# Altium schematic sheet PAGE3-POWER SUPPLY.SchDoc
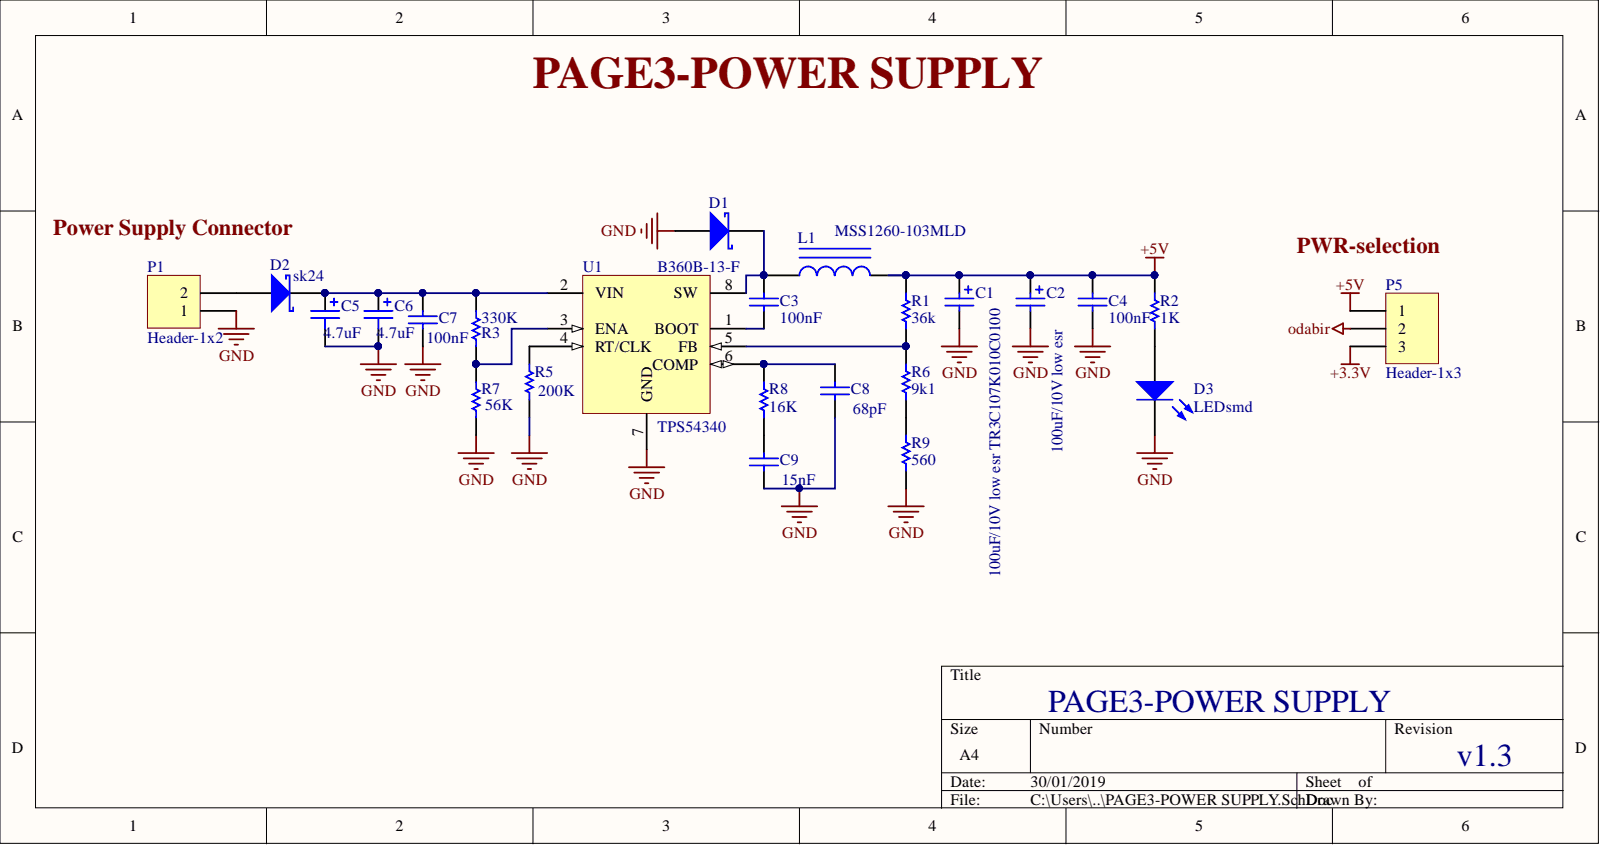
[diagram p0: PAGE3-POWER SUPPLY.SchDoc]

## Parts
C1  value 100uF/10V low esr TR3C107K010C0100  [TC7343-2917]  (hidden comment: CapTantalum)
C2  value 100uF/10V low esr  [TC7343-2917]  (hidden comment: Cap Pol2)
C3  value 100nF  [1206_cap]  (hidden comment: cap_smd1206)
C4  value 100nF  [1206_cap]  (hidden comment: cap_smd1206)
C5  4.7uF  [cap_smd1812]
C6  4.7uF  [cap_smd1812]
C7  100nF  [1206_cap]
C8  value 68pF  [1206_cap]  (hidden comment: cap_smd1206)
C9  value 15nF  [1206_cap]  (hidden comment: cap_smd1206)
D1  B360B-13-F  [DSO-C2/X3.3]
D2  sk24  [DSO-C2/X3.3]
D3  LEDsmd  [led1206]
L1  value MSS1260-103MLD  [INDUCTOR_SS1260-103MLD]  (hidden comment: Inductor_MSS1260)
P1  Header-1x2  [Header2Combination]
P5  Header-1x3  [HDR_1X3]
R1  value 36k  [1206_res]  (hidden comment: res)
R2  value 1K  [1206_res]  (hidden comment: res)
R3  value 330K  [1206_res]  (hidden comment: res)
R5  value 200K  [1206_res]  (hidden comment: res)
R6  value 9k1  [1206_res]  (hidden comment: res)
R7  value 56K  [1206_res]  (hidden comment: res)
R8  value 16K  [1206_res]  (hidden comment: res)
R9  value 560  [1206_res]  (hidden comment: res)
U1  TPS54340  [SOIC_8PIN]

## Nets
+3.3V: P5.3
+5V: C1.1 C2.1 C4.2 L1.2 P5.1 R1.2 R2.2
GND: C1.2 C2.2 C4.1 C5.2 C6.2 C7.1 C8.1 C9.1 D1.1 D3.2 P1.1 R5.1 R7.1 R9.1 U1.7(GND)
ODABIR: P5.2
NetC3_1: C3.1 U1.1(BOOT)
NetC3_2: C3.2 D1.2 L1.1 U1.8(SW)
NetC5_1: C5.1 C6.1 C7.2 D2.2 R3.2 U1.2(VIN)
NetC8_2: C8.2 R8.2 U1.6(COMP)
NetC9_2: C9.2 R8.1
NetD2_1: D2.1 P1.2
NetD3_1: D3.1 R2.1
NetR1_1: R1.1 R6.2 U1.5(FB)
NetR3_1: R3.1 R7.2 U1.3(ENA)
NetR5_2: R5.2 U1.4(RT/CLK)
NetR6_1: R6.1 R9.2

## Library data of the parts
C1 = CapTantalum: Polarized Capacitor (Radial); Value: 100uF/10V low esr TR3C107K010C0100; Component Kind: Standard; LatestRevisionDate: 17-Jul-2002; LatestRevisionNote: Re-released for DXP Platform.; PackageReference: RB7.6-15; Published: 8-Jun-2000; Signal Integrity: Cap Pol; Simulation: CAP; Library Name: hepek_lib.SchLib; Publisher: Altium Limited
C2 = Cap Pol2: Polarized Capacitor (Axial); Component Kind: Standard; LatestRevisionDate: 17-Jul-2002; LatestRevisionNote: Re-released for DXP Platform.; Library Name: Miscellaneous Devices.IntLib; PackageDescription: Polarized Capacitor; 2 Leads; PackageReference: POLAR0.8; Published: 8-Jun-2000; Signal Integrity: Cap Pol; Simulation: CAP; Publisher: Altium Limited
C3 C4 C8 C9 = cap_smd1206: Capacitor; Component Kind: Standard; LatestRevisionDate: 17-Jul-2002; LatestRevisionNote: Re-released for DXP Platform.; PackageReference: RAD-0.3; Published: 8-Jun-2000; Signal Integrity: Cap; Simulation: CAP; Library Name: hepek_lib.SchLib; Publisher: Altium Limited
C5 C6 = cap-smd1812: Polarized Capacitor (Axial); Value: X7R; Component Kind: Standard; LatestRevisionDate: 17-Jul-2002; LatestRevisionNote: Re-released for DXP Platform.; PackageDescription: Polarized Capacitor; 2 Leads; PackageReference: POLAR0.8; Published: 8-Jun-2000; Signal Integrity: Cap Pol; Simulation: CAP; Library Name: hepek_lib.SchLib; Publisher: Altium Limited
C7 = cap_smd: Capacitor; Component Kind: Standard; LatestRevisionDate: 17-Jul-2002; LatestRevisionNote: Re-released for DXP Platform.; PackageReference: RAD-0.3; Published: 8-Jun-2000; Signal Integrity: Cap; Simulation: CAP; Library Name: hepek_lib.SchLib; Publisher: Altium Limited
D1 D2 = SCHOTTKY_RECTIFIER_diode_SMB: Schottky Rectifier; Code_JEDEC: DO-204-AL; Component Kind: Standard; ComponentLink1Description: Manufacturer Link; ComponentLink1URL: http://www.irf.com/; ComponentLink2Description: Datasheet; ComponentLink2URL: http://www.irf.com/product-info/datasheets/data/11dq03.pdf; DatasheetDocument: Dec-1997; LatestRevisionDate: 17-Jul-2002; LatestRevisionNote: Re-released for DXP Platform.; PackageReference: DO-204AL; Published: 8-Jun-2000; Library Name: hepek_lib.SchLib; Publisher: Altium Limited
D3 = LEDsmd: Typical INFRARED GaAs LED; Component Kind: Standard; LatestRevisionDate: 08-Jul-2005; LatestRevisionNote: Mech Layer 1 removed.; PackageReference: LED-0; Published: 8-Jun-2000; Simulation: LED0; Library Name: hepek_lib.SchLib; Publisher: Altium Limited
L1 = Inductor_MSS1260: Magnetic-Core Inductor; Component Kind: Standard; LatestRevisionDate: 17-Jul-2002; LatestRevisionNote: Re-released for DXP Platform.; PackageDescription: Axial Device, Thru-Hole; 2 Leads; 0.9 in Pin Spacing; PackageReference: AXIAL-0.9; Published: 24-Mar-1999; Signal Integrity: Inductor Iron; Simulation: INDUCTOR; Library Name: hepek_lib.SchLib; Publisher: Altium Limited
P1 = Header2ConPow: Header, 2-Pin; Component Kind: Standard; LatestRevisionDate: 17-Jul-2002; LatestRevisionNote: Re-released for DXP Platform.; Signal Integrity: Connector; Library Name: hepek_lib.SchLib; Publisher: Altium Limited
P5 = header_3: Header, 3-Pin; Component Kind: Standard; LatestRevisionDate: 17-Jul-2002; LatestRevisionNote: Re-released for DXP Platform.; Signal Integrity: Connector; Library Name: hepek_lib.SchLib; Publisher: Altium Limited
R1-R3 R5-R9 = res: Resistor; Component Kind: Standard; LatestRevisionDate: 17-Jul-2002; LatestRevisionNote: Re-released for DXP Platform.; PackageReference: AXIAL-0.3; Published: 8-Jun-2000; Signal Integrity: Res; Simulation: RESISTOR; Library Name: hepek_lib.SchLib; Publisher: Altium Limited
U1 = TPS54340: Buck Step Down Regulator with 5.5 to 36 V Input and 1.23 to 31 V Output, -40 to 125 degC, 8-Pin SOIC (D), Green (RoHS & no Sb/Br); Manufacturer: Texas Instruments; PartNumber: TPS5420DG4; Duty Cycle(Max)(%): 87; Iout(Max)(A): 2; Iq(Typ)(mA): 3; Rating: Catalog; Regulated Outputs(#): 1; SubFamily: Step-Down Regulator; Vin(Max)(V): 36; Vin(Min)(V): 5.5; Vout(Max)(V): 31; Vout(Min)(V): 1.23; Code_JEDEC: MS-012AA; Component Kind: Standard; ComponentLink1Description: Datasheet; ComponentLink1URL: http://www.ti.com/general/docs/lit/getliterature.tsp?genericPartNumber=TPS5420&fileType=pdf; ComponentLink2Description: URL Link1; ComponentLink2URL: Samples URL:http://www.ti.com/product/tps5420#samples; DatasheetVersion: SLVS642C; Eco-Plan: Green (RoHS & no Sb/Br); Mounting Technology: Surface Mount; PackageDescription: 8-Pin Plastic Small Outline Integrated Circuit, 4.9 x 3.9 mm, Pitch 1.27 mm Pitch; PackageReference: D8; PackageVersion: revM, Jun-2011; Supplier 1: Digi-Key; Supplier 2: Farnell; Supplier 3: Newark; Supplier 4: Newark; Supplier 5: Mouser; Supplier Part Number 1: TPS5420DG4-ND; Supplier Part Number 2: 1262365; Supplier Part Number 3: 95M4483; Supplier Part Number 4: 99K4407; Supplier Part Number 5: 595-TPS5420DG4; Switch Current Limit(Typ)(A): 3; Topology: Buck; Library Name: hepek_lib.SchLib
